# Altium schematic sheet PowerSupply.SchDoc(PowerSupply)
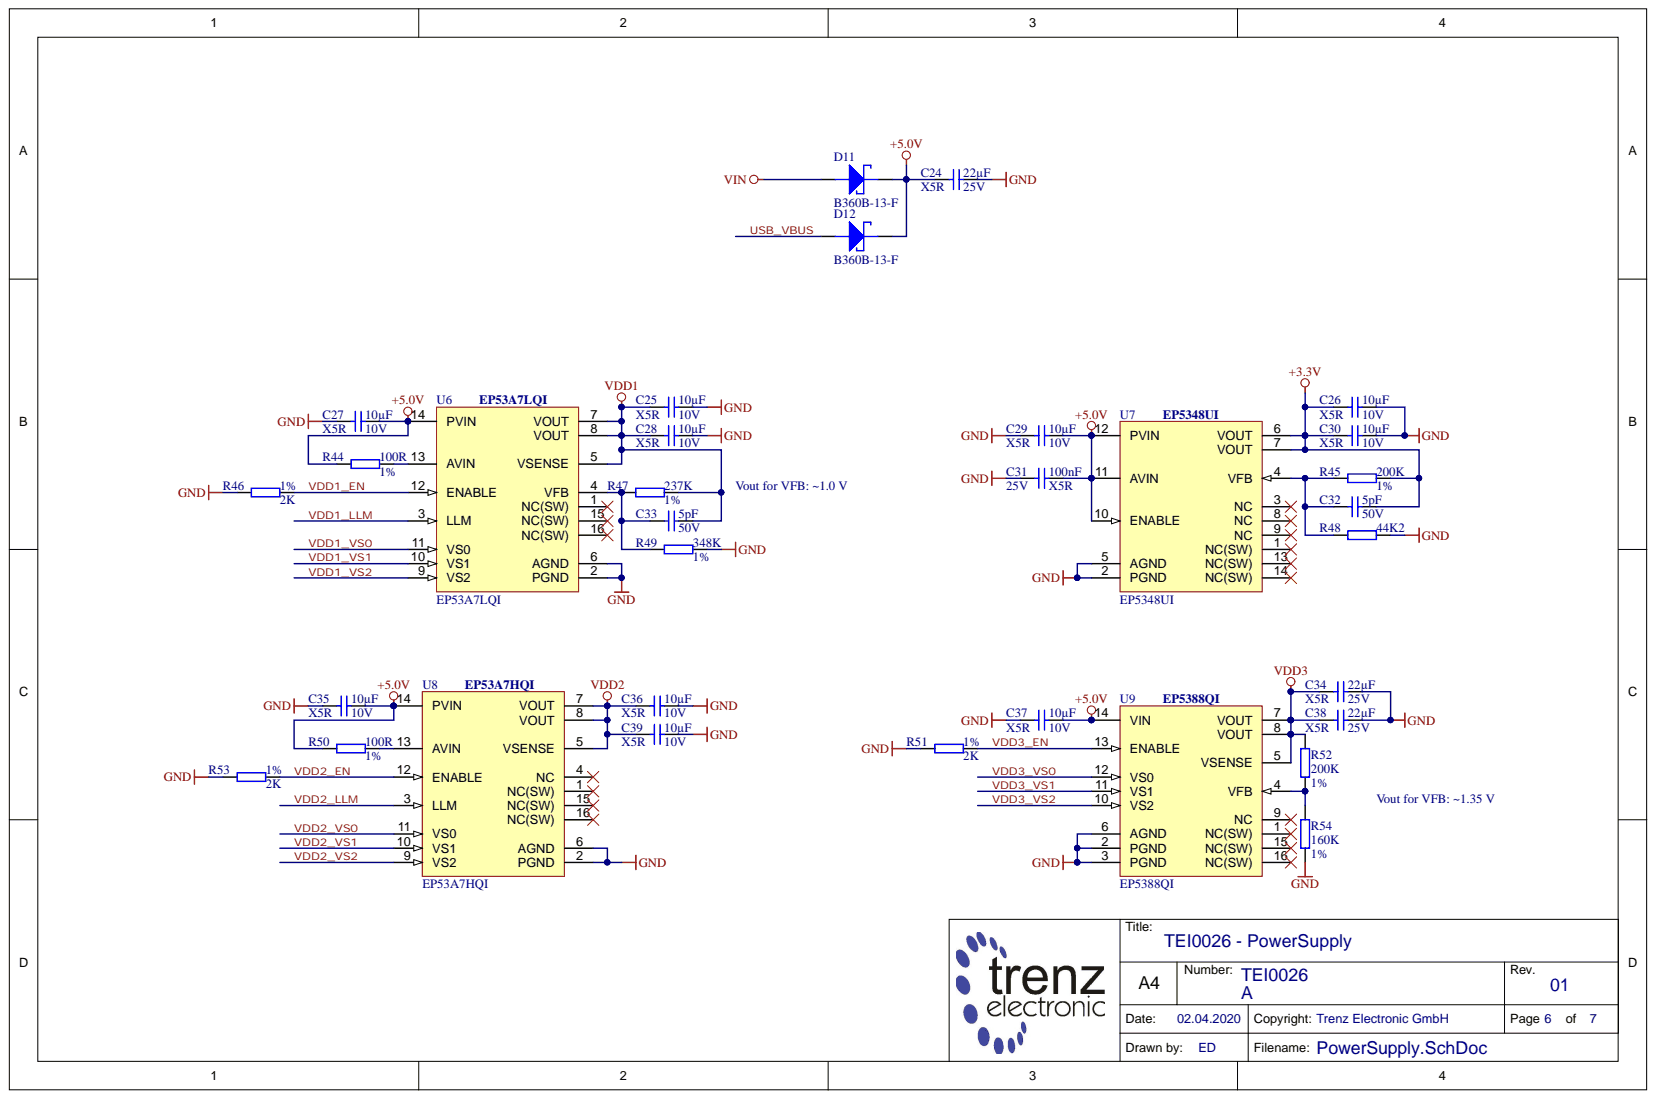
[diagram p0: PowerSupply.SchDoc]

## Parts
C24  22µF  Voltage: 25V  Technology: X5R  [CAPC2012X135N]
C25  10µF  Voltage: 10V  Technology: X5R  [CAPC2012X135N]
C26  10µF  Voltage: 10V  Technology: X5R  [CAPC2012X135N]
C27  10µF  Voltage: 10V  Technology: X5R  [CAPC2012X135N]
C28  10µF  Voltage: 10V  Technology: X5R  [CAPC2012X135N]
C29  10µF  Voltage: 10V  Technology: X5R  [CAPC2012X135N]
C30  10µF  Voltage: 10V  Technology: X5R  [CAPC2012X135N]
C31  100nF  Voltage: 25V  Technology: X5R  [CAPC1005X55N]
C32  5pF  Voltage: 50V  [CAPC1005X55N]
C33  5pF  Voltage: 50V  [CAPC1005X55N]
C34  22µF  Voltage: 25V  Technology: X5R  [CAPC2012X135N]
C35  10µF  Voltage: 10V  Technology: X5R  [CAPC2012X135N]
C36  10µF  Voltage: 10V  Technology: X5R  [CAPC2012X135N]
C37  10µF  Voltage: 10V  Technology: X5R  [CAPC2012X135N]
C38  22µF  Voltage: 25V  Technology: X5R  [CAPC2012X135N]
C39  10µF  Voltage: 10V  Technology: X5R  [CAPC2012X135N]
D11  B360B-13-F  [DIOM4336X250N]
D12  B360B-13-F  [DIOM4336X250N]
R44  100R  Tolerance: 1%  [RESC1005X40N]
R45  200K  Tolerance: 1%  [RESC1005X40N]
R46  2K  Tolerance: 1%  [RESC1005X40N]
R47  237K  Tolerance: 1%  [RESC1005X40N]
R48  44K2  [RESC1005X40N]
R49  348K  Tolerance: 1%  [RESC1005X40N]
R50  100R  Tolerance: 1%  [RESC1005X40N]
R51  2K  Tolerance: 1%  [RESC1005X40N]
R52  200K  Tolerance: 1%  [RESC1005X40N]
R53  2K  Tolerance: 1%  [RESC1005X40N]
R54  160K  Tolerance: 1%  [RESC1005X40N]
U6  EP53A7LQI  [EP53F8QI]
U7  EP5348UI  [QFN40P200X175X95-14N]
U8  EP53A7HQI  [EP53F8QI]
U9  EP5388QI  [EP53F8QI]

## Nets
+3.3V: C26.1 C30.1 C32.1 R45.2 U7.6(VOUT) U7.7(VOUT)
+5.0V: C24.2 C27.1 C29.1 C31.2 C35.1 C37.1 D11.1 D12.1 R44.1 R50.1 U6.14(PVIN) U7.10(ENABLE) U7.11(AVIN) U7.12(PVIN) U8.14(PVIN) U9.14(VIN)
GND: C24.1 C25.2 C26.2 C27.2 C28.2 C29.2 C30.2 C31.1 C34.2 C35.2 C36.2 C37.2 C38.2 C39.2 R46.1 R48.2 R49.2 R51.1 R53.1 R54.2 U6.2(PGND) U6.6(AGND) U7.2(PGND) U7.5(AGND) U8.2(PGND) U8.6(AGND) U9.2(PGND) U9.3(PGND) U9.6(AGND)
VDD1: C25.1 C28.1 C33.1 R47.2 U6.5(VSENSE) U6.7(VOUT) U6.8(VOUT)
VDD2: C36.1 C39.1 U8.5(VSENSE) U8.7(VOUT) U8.8(VOUT)
VDD3: C34.1 C38.1 R52.2 U9.5(VSENSE) U9.7(VOUT) U9.8(VOUT)
VIN: D11.2
USB_VBUS: D12.2
VDD1_EN: R46.2 U6.12(ENABLE)
VDD1_LLM: U6.3(LLM)
VDD1_VS0: U6.11(VS0)
VDD1_VS1: U6.10(VS1)
VDD1_VS2: U6.9(VS2)
VDD2_EN: R53.2 U8.12(ENABLE)
VDD2_LLM: U8.3(LLM)
VDD2_VS0: U8.11(VS0)
VDD2_VS1: U8.10(VS1)
VDD2_VS2: U8.9(VS2)
VDD3_EN: R51.2 U9.13(ENABLE)
VDD3_VS0: U9.12(VS0)
VDD3_VS1: U9.11(VS1)
VDD3_VS2: U9.10(VS2)
NetC32_2: C32.2 R45.1 R48.1 U7.4(VFB)
NetC33_2: C33.2 R47.1 R49.1 U6.4(VFB)
NetR44_2: R44.2 U6.13(AVIN)
NetR50_2: R50.2 U8.13(AVIN)
NetR52_1: R52.1 R54.1 U9.4(VFB)

Not connected: U6 1(NC(SW)) 15(NC(SW)) 16(NC(SW)); U7 1(NC(SW)) 3(NC) 8(NC) 9(NC) 13(NC(SW)) 14(NC(SW)); U8 1(NC(SW)) 4(NC) 15(NC(SW)) 16(NC(SW)); U9 1(NC(SW)) 9(NC) 15(NC(SW)) 16(NC(SW))

## Library data of the parts
C24 C34 C38 = 29556: KON 22µF 25V  X5R 20% SMD BF 2012 (0805); Value: 22u; Temperature-Range: -55/+85; Mixed: SMT; Database Table Name: C_2012_0805; Tolerance: 20%; Library Name: TE.DbLib; Component Kind: Standard; Case: 2012 (0805); AN: 29556
C25-C30 C35-C37 C39 = 28014: KON 10µF 10V X5R 10% SMD BF 2012 (0805); Value: 10u; Temperature-Range: -55/+85; Mixed: SMT; Database Table Name: C_2012_0805; Tolerance: 10%; Library Name: TE.DbLib; Component Kind: Standard; Case: 2012 (0805); AN: 28014
C31 = 22472: KON 100nF 25V X5R ±10% SMD BF 1005 (0402); Value: 100n; Temperature-Range: -55/+85; Preferred: Yes; Mixed: SMT; Database Table Name: C_1005_0402; Library Name: TE.DbLib; Component Kind: Standard; Case: 1005 (0402); AN: 22472
C32 C33 = 22848: KON 5pF NP0 50V SMD  BF 1005 (0402); Value: 5p; Mixed: SMT; Database Table Name: C_1005_0402; Library Name: TE.DbLib; Component Kind: Standard; Case: 1005 (0402); AN: 22848
D11 D12 = 24916: Mixed: SMT; Database Table Name: DIODE; Library Name: TE.DbLib; H-ART: B360B-13-F; Description: B360B-13-F; Component Kind: Standard; Case: SMB; AN: 24916; HelpURL: B:\AD\adlib\datasheet\B3x0B
R44 R50 = 22507: WID SMD 100R 1% 0,063W  BF 1005 (0402); Value: 100; Preferred: Yes; Mixed: SMT; H-ART: RC0402FR-13100RL; Database Table Name: R_1005_0402; Technology: TK100; Library Name: TE.DbLib; Component Kind: Standard; Case: 1005 (0402); AN: 22507
R45 R52 = 23846: WID SMD 200K 1% 0,063W  BF 1005 (0402); Preferred: Yes; Mixed: SMT; H-ART: RC0402FR-07200KL; Database Table Name: R_1005_0402; Value: 200K; Technology: TK100; Library Name: TE.DbLib; Component Kind: Standard; Case: 1005 (0402); AN: 23846
R46 R51 R53 = 25073: WID SMD 2K 1% 0,063W  BF 1005 (0402); Preferred: Yes; Mixed: SMT; H-ART: RC0402FR-072KL; Database Table Name: R_1005_0402; Value: 2K; Technology: TK100; Library Name: TE.DbLib; Component Kind: Standard; Case: 1005 (0402); AN: 25073
R47 = 24549: WID SMD 237K 1% 0,063W BF 1005 (0402); Preferred: Yes; Mixed: SMT; H-ART: RC0402FR-07237KL; Database Table Name: R_1005_0402; Value: 237K; Technology: TK100; Library Name: TE.DbLib; Component Kind: Standard; Case: 1005 (0402); AN: 24549
R48 = 30212: WID SMD 44K2 1% 0,063W; Value: 44.2K; Mixed: SMT; Database Table Name: R_1005_0402; Technology: TK100; Library Name: TE.DbLib; Component Kind: Standard; Case: 1005 (0402); AN: 30212
R49 = 29583: WID SMD 348K 1% 0,063W BF 1005 (0402); Voltage: 0.063W; Mixed: SMT; Database Table Name: R_1005_0402; Value: 348K; Technology: TK100; Library Name: TE.DbLib; Component Kind: Standard; Case: 1005 (0402); AN: 29583
R54 = 22934: WID SMD 160K 1% 0,063W  BF 1005 (0402); Preferred: Yes; Mixed: SMT; Database Table Name: R_1005_0402; Value: 160K; Technology: TK100; Library Name: TE.DbLib; Component Kind: Standard; Case: 1005 (0402); AN: 22934
U6 = 28280: Trenz-Stock: Yes; Temperature-Range: -40/+85; Mixed: SMT; Database Table Name: DCDC; Preferred: Yes; Library Name: TE.DbLib; H-ART: EP53A7LQI; Description: EP53A7LQI; Component Kind: Standard; Case: QFN16; AN: 28280; HelpURL: B:\AD\adlib\datasheet\EP53A7HQI
U7 = 30190: Temperature-Range: -40/+85; Mixed: SMT; Database Table Name: DCDC; Storage-Temperature: -65/+150(Abs); Library Name: TE.DbLib; H-ART: EP5348UI; Description: EP5348UI; Component Kind: Standard; Case: VFQFN14; AN: 30190; HelpURL: b:\AD\adlib\datasheet\Intel\EP5348UI\
U8 = 27996: Trenz-Stock: Yes; Temperature-Range: -40/+85; Mixed: SMT; Database Table Name: DCDC; Preferred: Yes; Library Name: TE.DbLib; H-ART: EP53A7HQI; Description: EP53A7HQI; Component Kind: Standard; Case: QFN16; AN: 27996; HelpURL: B:\AD\adlib\datasheet\EP53A7HQI
U9 = 30191: Temperature-Range: -40/+85; Mixed: SMT; Database Table Name: DCDC; Storage-Temperature: -65/+150(Abs); Library Name: TE.DbLib; H-ART: EP5388QI; Description: EP5388QI; Component Kind: Standard; Case: QFN16; AN: 30191; HelpURL: b:\AD\adlib\datasheet\Intel\EP5388QI\
